# Altium schematic sheet DAC.SchDoc
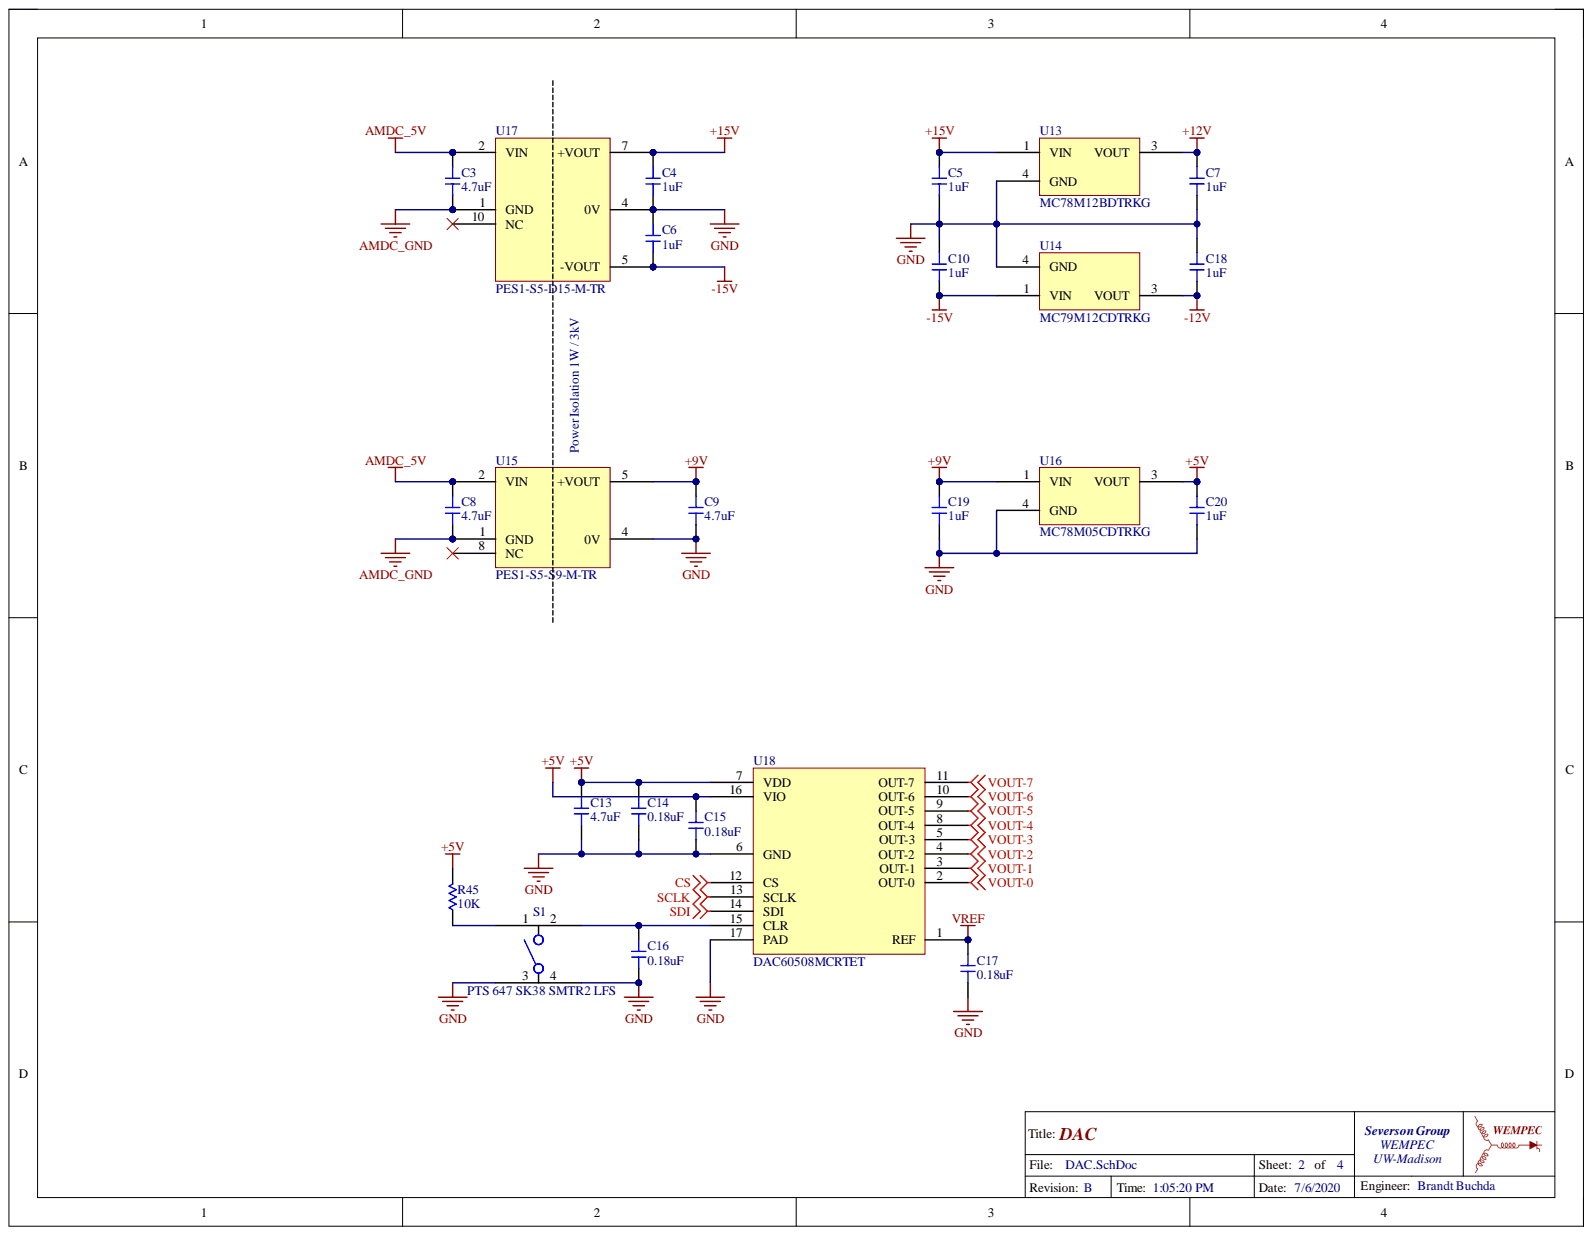
[diagram p0: DAC.SchDoc]

## Parts
C3  value 4.7uF  [0603-CAP]  (hidden comment: CL10A475KA8NQNC)
C4  value 1uF  [0603-CAP]  (hidden comment: CL10A105KP8NNNC)
C5  value 1uF  [0603-CAP]  (hidden comment: CL10A105KP8NNNC)
C6  value 1uF  [0603-CAP]  (hidden comment: CL10A105KP8NNNC)
C7  value 1uF  [0603-CAP]  (hidden comment: CL10A105KP8NNNC)
C8  value 4.7uF  [0603-CAP]  (hidden comment: CL10A475KA8NQNC)
C9  value 4.7uF  [0603-CAP]  (hidden comment: CL10A475KA8NQNC)
C10  value 1uF  [0603-CAP]  (hidden comment: CL10A105KP8NNNC)
C13  value 4.7uF  [0603-CAP]  (hidden comment: CL10A475KA8NQNC)
C14  value 0.18uF  [0603-CAP]  (hidden comment: CC0603KRX7R7BB184)
C15  value 0.18uF  [0603-CAP]  (hidden comment: CC0603KRX7R7BB184)
C16  value 0.18uF  [0603-CAP]  (hidden comment: CC0603KRX7R7BB184)
C17  value 0.18uF  [0603-CAP]  (hidden comment: CC0603KRX7R7BB184)
C18  value 1uF  [0603-CAP]  (hidden comment: CL10A105KP8NNNC)
C19  value 1uF  [0603-CAP]  (hidden comment: CL10A105KP8NNNC)
C20  value 1uF  [0603-CAP]  (hidden comment: CL10A105KP8NNNC)
R45  value 10K  [0603-RES]  (hidden comment: ERJ-PB3B1002V)
S1  PTS 647 SK38 SMTR2 LFS  [PTS647SK38SMTR2LFSCT-ND]
U13  MC78M12BDTRKG  [DPAK229P991X238-3N]
U14  MC79M12CDTRKG  [DPAK229P991X238-3N]
U15  PES1-S5-S9-M-TR  [CONV_PES1-S5-S9-M]
U16  MC78M05CDTRKG  [DPAK229P991X238-3N]
U17  PES1-S5-D15-M-TR  [CONV_PES1-S5-D24-M]
U18  DAC60508MCRTET  [QFN50P300X300X80_HS-17N]

## Nets
+5V: C13.2 C14.2 C15.2 C20.2 R45.2 U16.3 U18.7(VDD) U18.16(VIO)
+9V: C9.2 C19.2 U15.5(+VOUT) U16.1
+12V: C7.2 U13.3
+15V: C4.2 C5.2 U13.1 U17.7(+VOUT)
-12V: C18.1 U14.3
-15V: C6.1 C10.1 U14.1 U17.5(-VOUT)
AMDC_5V: C3.2 C8.2 U15.2(VIN) U17.2(VIN)
AMDC_GND: C3.1 C8.1 U15.1(GND) U17.1(GND)
CS: U18.12(CS)
GND: C4.1 C5.1 C6.2 C7.1 C9.1 C10.2 C13.1 C14.1 C15.1 C16.1 C17.1 C18.2 C19.1 C20.1 S1.3 S1.4 U13.4 U14.4 U15.4(0V) U16.4 U17.4(0V) U18.6(GND) U18.17(PAD)
SCLK: U18.13(SCLK)
SDI: U18.14(SDI)
VOUT-0: U18.2(OUT-0)
VOUT-1: U18.3(OUT-1)
VOUT-2: U18.4(OUT-2)
VOUT-3: U18.5(OUT-3)
VOUT-4: U18.8(OUT-4)
VOUT-5: U18.9(OUT-5)
VOUT-6: U18.10(OUT-6)
VOUT-7: U18.11(OUT-7)
VREF: C17.2 U18.1(REF)
NetC16_2: C16.2 S1.2 U18.15(CLR)
NetR45_1: R45.1 S1.1

Not connected: U15 8(NC); U17 10(NC)

## Library data of the parts
C3 C8 C9 C13 = Capacitor 4.7uF: 4.7µF ±10% 25V Ceramic Capacitor X5R 0603 (1608 Metric); Component Kind: Standard; Simulation: CAP; Supplier 1: Digi-Key; Supplier Part Number 1: 1276-1900-1-ND; Library Name: DAC.SchLib
C4-C7 C10 C18-C20 = Capacitor 1uF: 1µF ±10% 10V Ceramic Capacitor X5R 0603 (1608 Metric); Component Kind: Standard; Simulation: CAP; Supplier 1: Digi-Key; Supplier Part Number 1: 1276-1182-1-ND; Library Name: DAC.SchLib
C14-C17 = Capacitor 0.18uF: 0.18µF ±10% 16V Ceramic Capacitor X7R 0603 (1608 Metric); Component Kind: Standard; Simulation: CAP; Supplier 1: Digi-Key; Supplier Part Number 1: 311-4066-1-ND; Library Name: DAC.SchLib
R45 = Resistor 10K: 10 kOhms ±0.1% 0.2W, 1/5W Chip Resistor 0603 (1608 Metric) Automotive AEC-Q200, Pulse Withstanding Thick Film; Component Kind: Standard; Simulation: RES; Supplier 1: Digi-Key; Supplier Part Number 1: P20086CT-ND; Library Name: DAC.SchLib
S1 = PTS 647 SK38 SMTR2 LFS: Tactile Switch SPST-NO Top Actuated Surface Mount; Component Kind: Standard; Supplier 1: Digi-Key; Supplier Part Number 1: PTS647SK38SMTR2LFSCT-ND; Library Name: DAC.SchLib
U13 = MC78M12BDTRKG: +12 Linear Voltage Regulator IC  1 Output  500mA DPAK; Component Kind: Standard; Supplier 1: Digi-Key; Supplier Part Number 1: MC78M12BDTRKGOSCT-ND; Library Name: DAC.SchLib
U14 = MC79M12CDTRKG: -12 Linear Voltage Regulator IC  1 Output  500mA DPAK; Component Kind: Standard; Supplier 1: Digi-Key; Supplier Part Number 1: MC79M12CDTRKGOSCT-ND; Library Name: DAC.SchLib
U15 = PES1-S5-S9-M-TR: Isolated Module DC DC Converter 1 Output 9V    111mA 4.5V - 5.5V Input; Component Kind: Standard; Supplier 1: Digi-Key; Supplier Part Number 1: 102-2980-1-ND; Library Name: DAC.SchLib
U16 = MC78M05CDTRKG: +5V Linear Voltage Regulator IC  1 Output  500mA DPAK; Component Kind: Standard; Supplier 1: Digi-Key; Supplier Part Number 1: MC78M05CDTRKGOSCT-ND; Library Name: DAC.SchLib
U17 = PES1-S5-D15-M-TR: Isolated Module DC DC Converter 2 Output 15V -15V   21mA, 21mA 4.5V - 5.5V Input; Component Kind: Standard; Supplier 1: Digi-Key; Supplier Part Number 1: 102-3328-1-ND; Library Name: DAC.SchLib
U18 = DAC60508MCRTET: 12 Bit Digital to Analog Converter 8 16-WQFN (3x3); Component Kind: Standard; Library Name: DAC.SchLib; Supplier 1: Digi-Key; Supplier Part Number 1: 296-52288-1-ND
